# Altium schematic sheet POWER_PART.SchDoc(POWER_PART)
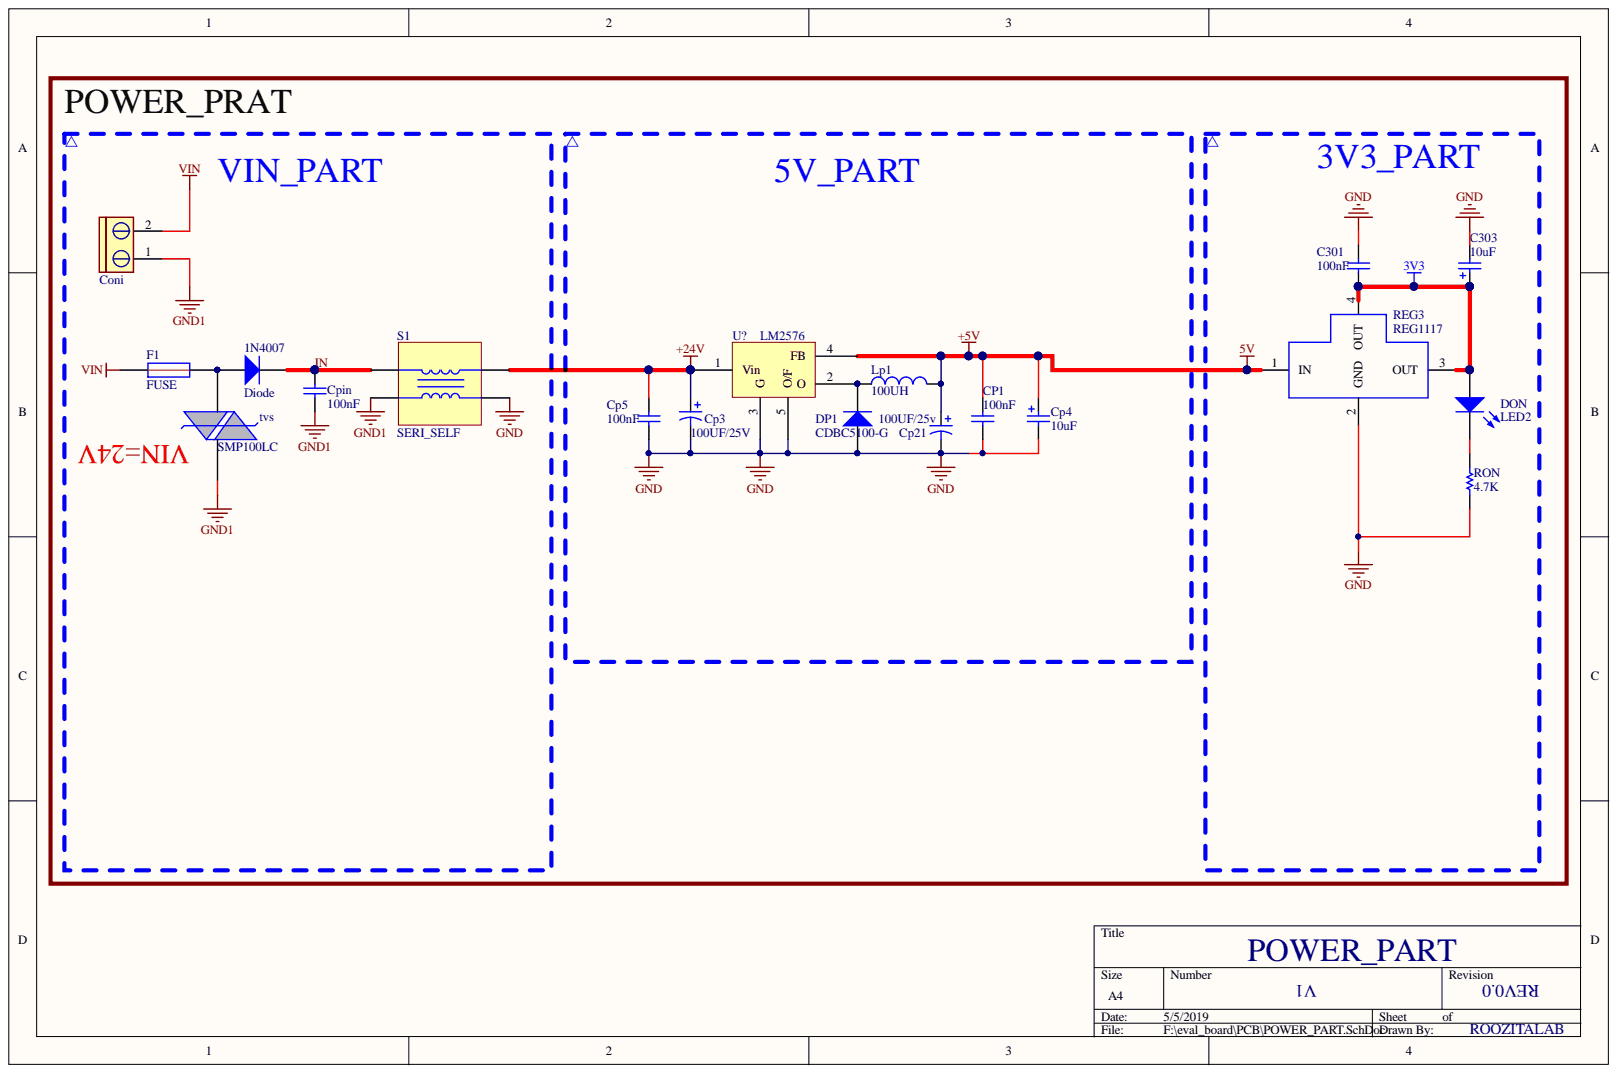
[diagram p0: POWER_PART.SchDoc]

## Parts
1N4007  Diode  [SMB]
C301  100nF  [0805.]
C303  10uF  [C1206.]
Coni  CON2 pin blue  [My_CN2]  (value not drawn)
CP1  100nF  [0805.]
Cp3  value 100UF/25V  [100UF25V]  (hidden comment: Cap Pol1)
Cp4  10uF  [C1206.]
Cp5  100nF  [0805.]
Cp21  value 100UF/25v  [100UF25V]  (hidden comment: Cap Pol1)
Cpin  100nF  [0805.]
DON  LED2  [0805.]
DP1  CDBC5100-G  [MY_SMC]
F1  FUSE  [1206]
Lp1  value 100UH  [indoctor100u]  (hidden comment: Inductor)
REG3  REG1117  [REG SMD]
RON  4.7K  [0805.]
S1  SERI_SELF  [SERI_SELF_SMALL]
tvs  SMP100LC  [TVS]
U?  LM2576  [d2pak5-lead]

## Nets
3V3: C301.1 C303.1 DON.1 REG3.3(OUT) REG3.4(OUT)
+5V: CP1.2 Cp4.1 Cp21.1 Lp1.2 REG3.1(IN) U?.4(FB)
+24V: Cp3.1 Cp5.2 S1.3 U?.1(Vin)
GND: C301.2 C303.2 CP1.1 Cp3.2 Cp4.2 Cp5.1 Cp21.2 DP1.A REG3.2(GND) RON.1 S1.4 U?.3(G) U?.5(O/F)
GND1: Coni.1 Cpin.2 S1.2 tvs.2
VIN: Coni.2 F1.2
IN: 1N4007.2 Cpin.1 S1.1
Net1N4007_1: 1N4007.1 F1.1 tvs.1
NetDON_2: DON.2 RON.2
NetDP1_K: DP1.K Lp1.1 U?.2(O)

## Library data of the parts
1N4007 = Diode: Default Diode; Simulation: DIODE; Signal Integrity: Diode; Published: 8-Jun-2000; PackageVersion: Sep-1996; PackageReference: SMC; PackageDescription: DO-214-AB/SMC; 2 C-Bend Leads; Body 7.9 x 5.9 mm, inc. leads (LxW); Library Name: Miscellaneous Devices.IntLib; LatestRevisionNote: Re-released for DXP Platform.; LatestRevisionDate: 17-Jul-2002; Component Kind: Standard; Code_JEDEC: DO-214-AB; Publisher: Altium Limited
C301 CP1 Cp5 Cpin = Cap: Capacitor; Value: 100nF; Simulation: CAP; Signal Integrity: Cap; Published: 8-Jun-2000; PackageReference: RAD-0.3; PackageDescription: Radial Cap, Thru-Hole; 2 Leads; 0.3 in Pin Spacing; Library Name: STM32F407_144.IntLib; LatestRevisionNote: Re-released for DXP Platform.; LatestRevisionDate: 17-Jul-2002; Component Kind: Standard; Publisher: Altium Limited
C303 Cp3 Cp4 Cp21 = Cap Pol1: Polarized Capacitor (Radial); Simulation: CAP; Published: 8-Jun-2000; Component Kind: Standard; Publisher: Altium Limited
  C303 Cp4: Value: 10uF; Signal Integrity: C200M; Revision: July-2002: Re-released for DXP Platform.; Library Name: STM32F407_144.IntLib
  Cp3 Cp21: Signal Integrity: Cap Pol; PackageReference: RB7.6-15; Library Name: Miscellaneous Devices.IntLib; LatestRevisionNote: Re-released for DXP Platform.; LatestRevisionDate: 17-Jul-2002
Coni = CON2 pin blue: Connector blue screw; Library Name: Mylib.IntLib; Component Kind: Standard
DON = LED2: Typical RED, GREEN, YELLOW, AMBER GaAs LED; Simulation: LED2; Published: 8-Jun-2000; PackageVersion: Feb-2002; PackageReference: 3.2X1.6X1.1; PackageDescription: SM LED; 2 Flat Leads; Library Name: STM32F407_144.IntLib; LatestRevisionNote: Re-released for DXP Platform.; LatestRevisionDate: 17-Jul-2002; Component Kind: Standard; Publisher: Altium Limited
DP1 = 1N4007: 1 Amp Diode; Library Name: TPS54331.IntLib; Component Kind: Standard
Lp1 = Inductor: Code_IEC: 1005; Simulation: INDUCTOR; Signal Integrity: Inductor; Published: 8-Jun-2000; PackageReference: 0402-A; Library Name: Miscellaneous Devices.IntLib; LatestRevisionNote: Re-released for DXP Platform.; LatestRevisionDate: 17-Jul-2002; Description: Inductor; Component Kind: Standard; Code_JEITA: 0402; Publisher: Altium Limited
RON = Res1: Resistor; Value: 1K; Simulation: RESISTOR; Signal Integrity: Res; Revision: July-2002: Re-released for DXP Platform.; Published: 8-Jun-2000; Library Name: STM32F407_144.IntLib; Component Kind: Standard; Publisher: Altium Limited
U? = LM2576-5V: Component Kind: Standard; Library Name: LPC.SchLib
F1 = fuse: Library Name: my dxp lib.IntLib; Component Kind: Standard
REG3 = REG1117: Library Name: STM32F407_144.IntLib; Component Kind: Standard
S1 = SERI_SELF: Library Name: my dxp lib.IntLib; Component Kind: Standard
tvs = SMP100LC: Library Name: my dxp lib.IntLib; Component Kind: Standard
